# Altium schematic sheet 04 - USB, QSPI, SHA, I2C EEPROM.SchDoc
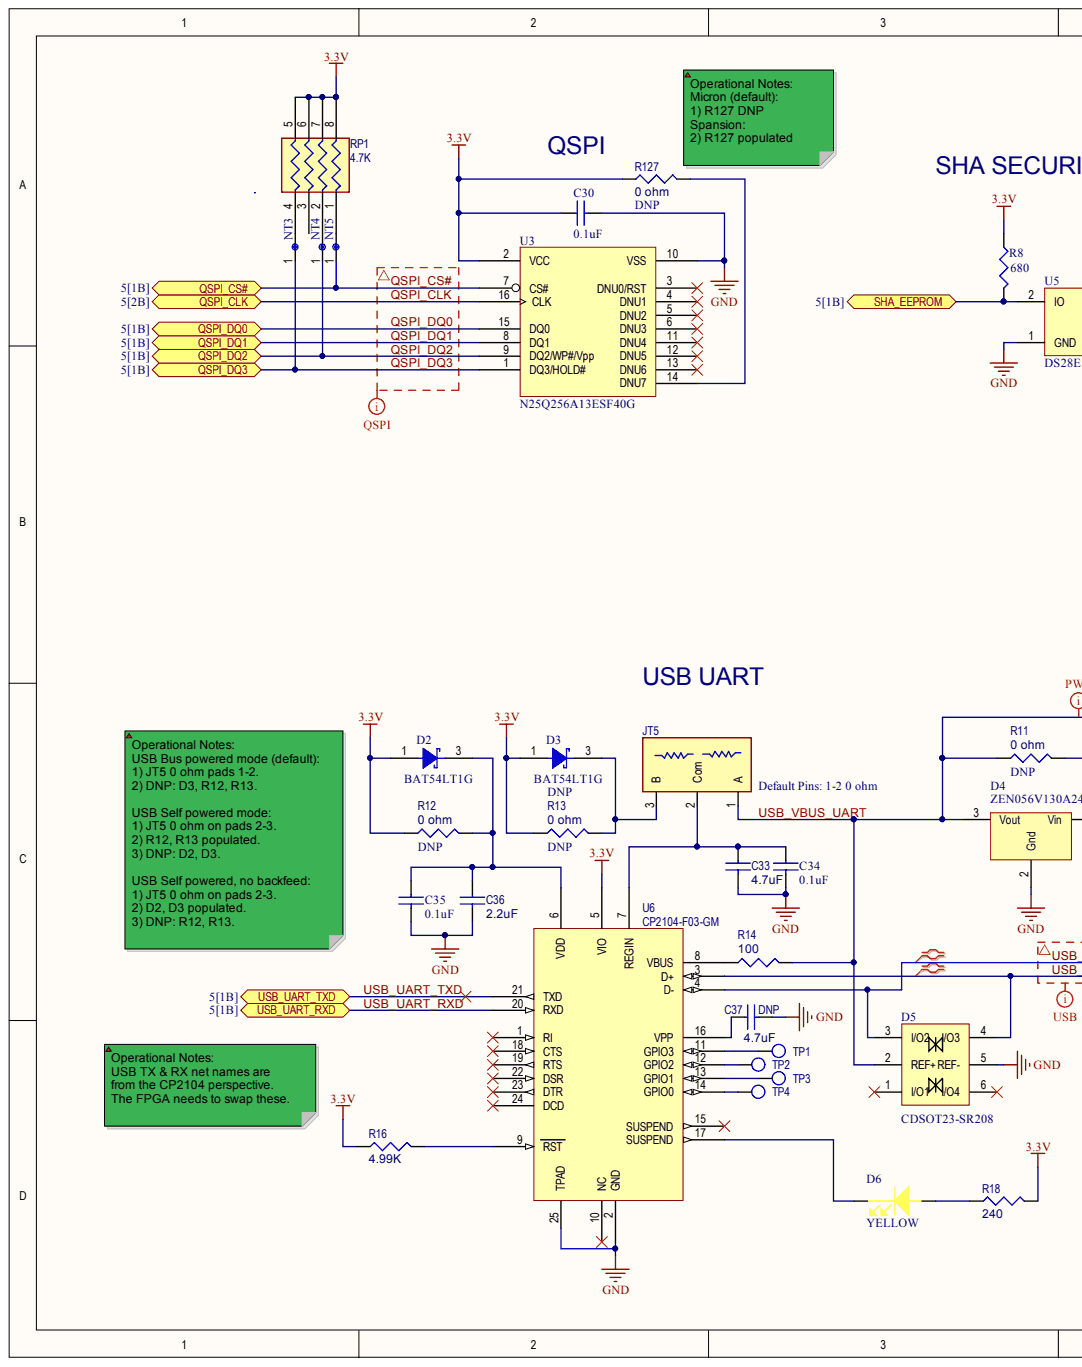
[diagram p0: 04 - USB, QSPI, SHA, I2C EEPROM.SchDoc, left]
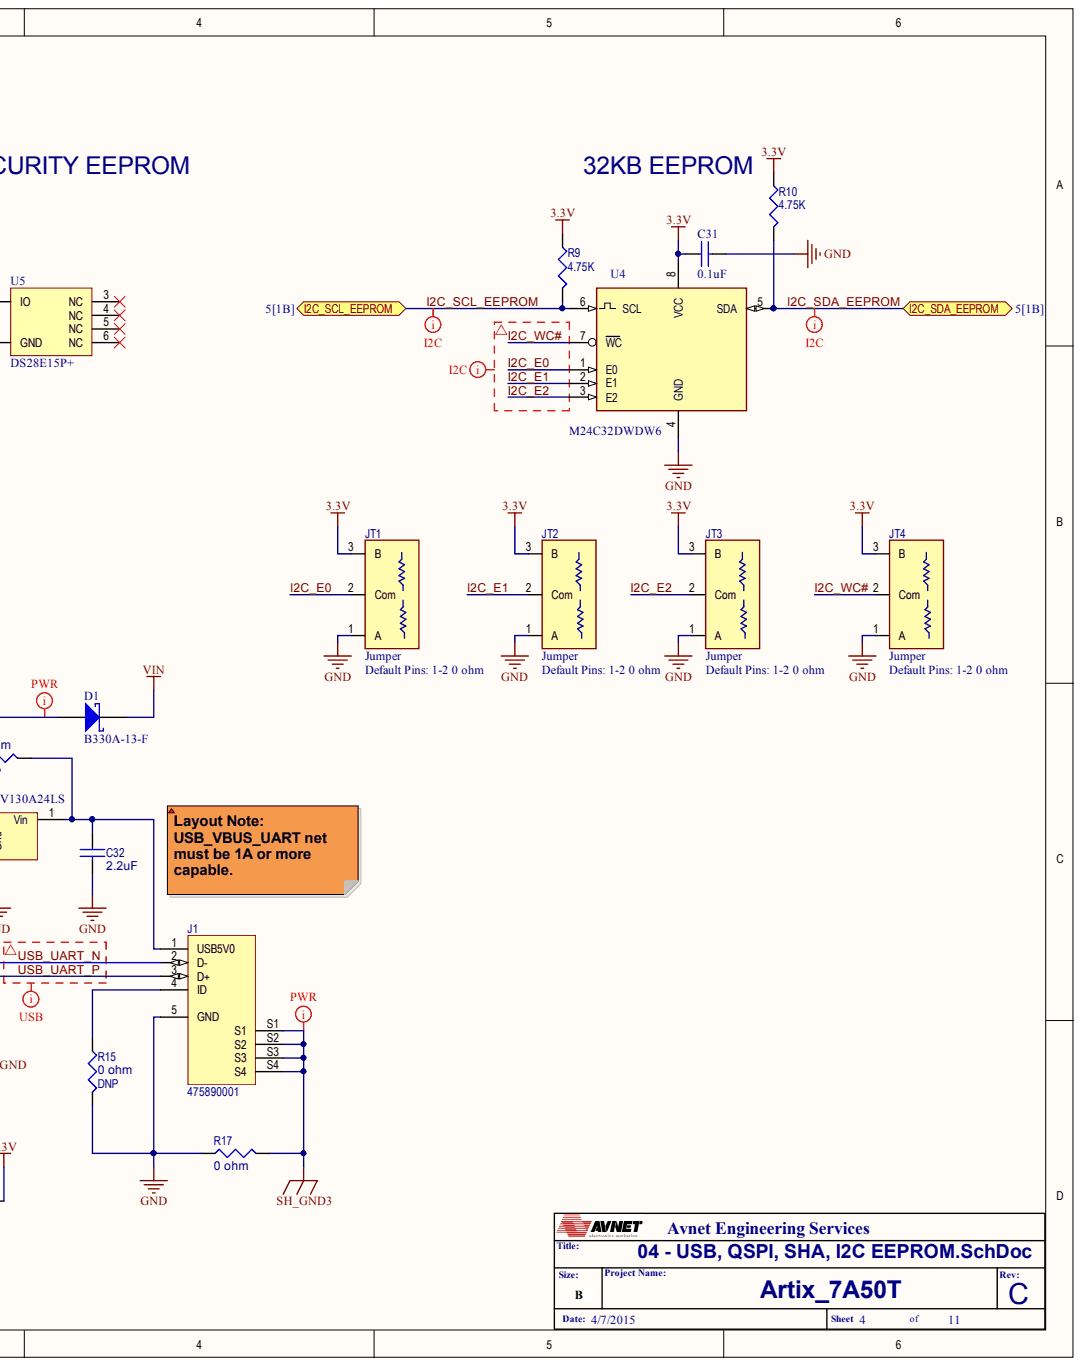
[diagram p1: 04 - USB, QSPI, SHA, I2C EEPROM.SchDoc, right]

## Parts
C30  value 0.1uF  [C0201]  (hidden comment: PC522)
C31  value 0.1uF  [C0201]  (hidden comment: PC522)
C32  2.2uF  [C0603]
C33  value 4.7uF  [C0402]  (hidden comment: 4.8uF)
C34  value 0.1uF  [C0201]  (hidden comment: PC522)
C35  value 0.1uF  [C0201]  (hidden comment: PC522)
C36  2.2uF  [C0603]
C37  value 4.7uF  [C0402]  (hidden comment: 4.8uF)
D1  Device: B330A-13-F  [SMA]  (hidden comment: DD031)
D2  BAT54LT1G  [SOT23]
D3  BAT54LT1G  [SOT23]
D4  Device: ZEN056V130A24LS  [PolyZen]  (hidden comment: POLY_ZEN)
D5  CDSOT23-SR208  [SOT23-6]
D6  Device: YELLOW  [LED_LITE-LTST-C191TBKT-Y]  (hidden comment: DL017)
J1  475890001  [MOLEX_0475890001]
JT1  value 0 ohm  Device: Jumper  [R0402-DUAL_JMP]
JT2  value 0 ohm  Device: Jumper  [R0402-DUAL_JMP]
JT3  value 0 ohm  Device: Jumper  [R0402-DUAL_JMP]
JT4  value 0 ohm  Device: Jumper  [R0402-DUAL_JMP]
JT5  value 0 ohm  [R0402-DUAL_JMP]
NT3  PO030  [NT2X4-2210]  (value not drawn)
NT4  PO030  [NT2X4-2210]  (value not drawn)
NT5  PO030  [NT2X4-2210]  (value not drawn)
R8  680R  value 680  [R0603]
R9  value 4.75K  [R0402]  (hidden comment: 0402)
R10  value 4.75K  [R0402]  (hidden comment: 0402)
R11  0 ohm  [R0402]
R12  0 ohm  [R0402]
R13  0 ohm  [R0402]
R14  100  [R0402]
R15  0 ohm  [R0402]
R16  4.99K  [R0402]
R17  0 ohm  [R0402]
R18  240  [R0402]
R127  0 ohm  [R0402]
RP1  value 4.7K  [RPACK4-LCC]  (hidden comment: CAT16-472J4LF)
TP1  TPS  [TP24TH]  (value not drawn)
TP2  TPS  [TP24TH]  (value not drawn)
TP3  TPS  [TP24TH]  (value not drawn)
TP4  TPS  [TP24TH]  (value not drawn)
U3  N25Q256A13ESF40G  [so16L]
U4  MFGPN: M24C32DWDW6  [TSSOP8]  (hidden comment: ST_M24C32_TSSOP)
U5  DS28E15P+  [TSOC6]
U6  CP2104-F03-GM  [QFN24_4x4mm]

## Ports
I2C_SCL_EEPROM, I2C_SDA_EEPROM, QSPI_CLK, QSPI_CS#, QSPI_DQ0, QSPI_DQ1, QSPI_DQ2, QSPI_DQ3, SHA_EEPROM, USB_UART_RXD, USB_UART_TXD

## Nets
3.3V: C30.1 C31.1 D2.1 D3.1 JT1.3 JT2.3 JT3.3 JT4.3 R8.1 R9.1 R10.1 R12.1 R13.1 R16.1 R18.2 R127.1 RP1.5 RP1.6 RP1.7 RP1.8 U3.2(VCC) U4.8(VCC) U6.5(VIO)
GND: C30.2 C31.2 C32.2 C33.2 C34.2 C35.2 C36.2 C37.2 D4.2 D5.5(REF-) J1.5(GND) JT1.1 JT2.1 JT3.1 JT4.1 R15.2 R17.1 U3.10(VSS) U4.4(GND) U5.1(GND) U6.2(GND) U6.25(TPAD)
SH_GND3: J1.S1 J1.S2 J1.S3 J1.S4 R17.2
VIN: D1.C
I2C_E0: JT1.2 U4.1(E0)
I2C_E1: JT2.2 U4.2(E1)
I2C_E2: JT3.2 U4.3(E2)
I2C_SCL_EEPROM: R9.2 U4.6 ; port I2C_SCL_EEPROM
I2C_SDA_EEPROM: R10.2 U4.5(SDA) ; port I2C_SDA_EEPROM
I2C_WC#: JT4.2 U4.7(WC)
QSPI_CLK: U3.16(CLK) ; port QSPI_CLK
QSPI_CS#: NT5.1 U3.7(CS#) ; port QSPI_CS#
QSPI_DQ0: U3.15(DQ0) ; port QSPI_DQ0
QSPI_DQ1: U3.8(DQ1) ; port QSPI_DQ1
QSPI_DQ2: NT4.1 U3.9(DQ2/WP#/Vpp) ; port QSPI_DQ2
QSPI_DQ3: NT3.1 U3.1(DQ3/HOLD#) ; port QSPI_DQ3
SHA_EEPROM: R8.2 U5.2(IO) ; port SHA_EEPROM
USB_UART_N: D5.3(I/O2) J1.2(D-) U6.4(D-)
USB_UART_P: D5.4(I/O3) J1.3(D+) U6.3(D+)
USB_UART_RXD: U6.20(RXD) ; port USB_UART_RXD
USB_UART_TXD: U6.21(TXD) ; port USB_UART_TXD
USB_VBUS_UART: D1.A D4.3 D5.2(REF+) JT5.1 R11.1 R14.2
NetC32_1: C32.1 D4.1 J1.1(USB5V0) R11.2
NetC33_1: C33.1 C34.1 JT5.2 U6.7(REGIN)
NetC35_1: C35.1 C36.1 D2.3 R12.2 U6.6(VDD)
NetC37_1: C37.1 U6.16(VPP)
NetD3_3: D3.3 JT5.3 R13.2
NetD6_A: D6.A R18.1
NetD6_C: D6.C U6.17(SUSPEND)
NetJ1_4: J1.4(ID) R15.1
NetNT3_2: NT3.2 RP1.4
NetNT4_2: NT4.2 RP1.2
NetNT5_2: NT5.2 RP1.1
NetR14_1: R14.1 U6.8(VBUS)
NetR16_2: R16.2 U6.9(RST)
NetR127_2: R127.2 U3.14(DNU7)
NetTP1_1: TP1.1 U6.11(GPIO3)
NetTP2_1: TP2.1 U6.12(GPIO2)
NetTP3_1: TP3.1 U6.13(GPIO1)
NetTP4_1: TP4.1 U6.14(GPIO0)
N00105:
N00106:
N00107:

Not connected: D5 1(I/O1) 6(I/O4); RP1 3; U3 3(DNU0/RST) 4(DNU1) 5(DNU2) 6(DNU3) 11(DNU4) 12(DNU5) 13(DNU6); U5 3(NC) 4(NC) 5(NC) 6(NC); U6 1(RI) 10(NC) 15(SUSPEND) 18(CTS) 19(RTS) 22(DSR) 23(DTR) 24(DCD)

## Library data of the parts
C30 C31 C34 C35 = AVPC-0000522: CAP SM 0.1uF 10V 10% X5R C0201; PartCode: PC; Database Table Name: Passive-Cap$; MFG Code: AVX; MFGPN: 02016D104KAT2A; AsyTxt: PC522; AVLNo: AVPC-0000522; Component Kind: Standard; ComponentLink1Description: Datasheet; Device: CAP; Dielectric: X5R; PkgType: SM; RoHS: Yes; Status: Preliminary; Tol: 10%; Volt: 10V; ComponentLink1URL: C:\AVDBLIB\DATASHEETS\AVX_CCOG.pdf; Library Name: Avnet_DBlib.DbLib
C32 C36 = AVPC-0000155: CAP SM 2.2uF 6.3V 10% X5R C0603; PartCode: PC; AsyTxt: PC155; Database Table Name: Passive-Cap$; MFG Code: AVX; MFGPN: 06036D225KAT2A; Power: 0; Availability: 4K-AV; AVLNo: AVPC-0000155; Component Kind: Standard; ComponentLink1Description: Datasheet; ComponentLink2Description: MFR Website; ComponentLink2URL: www.avx.com; Cost: 0.04; Device: CAP; Dielectric: X5R; PkgType: SM; Received: 0; RoHS: Yes; Size: 3.4; Status: Preliminary; Tol: 10%; Value: 2.2uF; Volt: 6.3V; ComponentLink1URL: C:\AVDBLIB\DATASHEETS\AVX_CX5R.pdf; Library Name: Avnet_DBlib.DbLib
C33 C37 = AVPC-0000499: CAP SM 4.7uF 6.3V 20% X5R C0402 85C; PartCode: PC; AsyTxt: PC499; Database Table Name: Passive-Cap$; MFG Code: AVX; MFGPN: GRM155R60J475ME87D; Power: 0; Availability: 140K-DK; AVLNo: AVPC-0000499; Component Kind: Standard; ComponentLink1Description: Datasheet; Cost: .46; Device: CAP; Dielectric: X5R; PkgType: SM; Received: 0; RoHS: Yes; Size: 1.9; Status: Preliminary; Tol: 20%; Volt: 6.3V; ComponentLink1URL: C:\AVDBLIB\DATASHEETS\murata_caps_c02e.pdf; Library Name: Avnet_DBlib.DbLib
  C37: DNP: DNP
D1 = AVDD-0000031: Diode SM Barrier Rectifier Schottky 3A 30V    DIO-S SMA; PartCode: DD; Database Table Name: Discrete-Diode$; MFG Code: DII; AsyTxt: DD031; AVLNo: AVDD-0000031; Component Kind: Standard; Device Sub Type: Barrier Rectifier; Device Type: Diode; Imax: 3A; MFGPN: B330A-13-F; PkgType: SM; Status: Preliminary; Technology: Schottky; Vr: 30V; Library Name: Avnet_DBlib.DbLib
D2 D3 = BAT54LT1G: Schottky Diodes Vf=0.35V@10mA Vr=30V; Component Kind: Standard; Library Name: new.SchLib; MFGPN: BAT54LT1G
  D3: DNP: DNP
D4 = AVDO-0000003: OVP SM Over Voltage Protection 1.3A 24V 5.6V   POLY_ZEN PolyZen; PartCode: DO; AsyTxt: DO003; Database Table Name: Discrete-Other$; MFG Code: RCP; AVLNo: AVDO-0000003; Component Kind: Standard; ComponentLink1Description: Datasheet; Device Type: OVP; Imax: 1.3A; MFGPN: ZEN056V130A24LS; PkgType: SM; RoHS: YES; Status: Preliminary; Technology: Over Voltage Protection; Vf: 5.6V; Vr: 24V; ComponentLink1URL: C:\AVDBLIB\DATASHEETS\polyzends.pdf; Library Name: Avnet_DBlib.DbLib
D5 = CDSOT23-SR208: ESD Protection array; AVLNo: AVDO-0000008; MFG: BNS; Component Kind: Standard; Device: CDSOT23-SR208; Library Name: Zynq_Z010_ZedStick.SchLib; MFGPN: CDSOT23-SR208
D6 = AVDL-0000017: LED, Yel, 585nm, Vf=2.1V, If=10mA, lv=6mcd; PartCode: DL; Database Table Name: Discrete-LED$; If: 10mA; LV: 6mcd; MFG Code: LTP; MFGPN: LTST-C190YKT; PkgType: SM; Vf: 2.1V; AsyTxt: DL017; AVLNo: AVDL-0000017; Component Kind: Standard; ComponentLink1Description: Datasheet; Status: Preliminary; WaveLength: 585nM; ComponentLink1URL: C:\AVDBLIB\DATASHEETS\ltst-c190ykt.pdf; Library Name: Avnet_DBlib.DbLib
J1 = AVEC-0000011,A-0: CONN RCPT MICRO USB AB R/A SMD; Mount Type (SM/TH): SM; Preferred MFG: MOLEX; Preferrence Code: A; Component Kind: Standard; Component Type: CONNECTOR; Material: MICRO USB; PCB Footprints: MOLEX_0475890001; Preferred MFGPN: 475890001; RoHS: Y; Library Name: AES ALTIUM VAULT
JT1-JT4 = AVPO-0000025: Jumper 3-pad 0402 RES-3padJPR R0402-DUAL_JMP - 0 Ohm at Pads 1-2; PartCode: PO; Database Table Name: Passive-Other$; Default Pins: 1-2 0 ohm; Device Type: 3-pad 0R0 0402; MFG Code: DAL; MFGPN: CRCW04020000Z0ED: Placed at pads 1-2; PkgType: SM; Component Kind: Standard; ComponentLink1Description: Datasheet; RoHS: Yes; Status: Preliminary; ComponentLink1URL: C:\AVDBLIB\DATASHEETS\Dale_CRCW.pd; Library Name: Avnet_DBlib.DbLib
JT5 = AVPO-0000025: Jumper 3-pad 0402 RES-3padJPR R0402-DUAL_JMP - 0 Ohm at Pads 1-2; PartCode: PO; Database Table Name: Passive-Other$; Default Pins: 1-2 0 ohm; Device Type: 3-pad 0R0 0402; MFG Code: DAL; MFGPN: CRCW04020000Z0ED: Placed at pads 1-2; PkgType: SM; Value: 0 ohm; Component Kind: Standard; ComponentLink1Description: Datasheet; Device: Jumper; RoHS: Yes; Status: Preliminary; ComponentLink1URL: C:\AVDBLIB\DATASHEETS\Dale_CRCW.pd; Library Name: Avnet_DBlib.DbLib
NT3-NT5 = AVPO-0000030: Net Tie 2 points; PartCode: PO; Database Table Name: Passive-Other$; MFG Code: None; PkgType: SM; AsyTxt: PO030; AVLNo: AVPO-0000030; Component Kind: Standard; ComponentLink1Description: Datasheet; MFGPN: None; RoHS: Yes; Status: Preliminary; ComponentLink1URL: C:\AVDBLIB\DATASHEETS\; Library Name: Avnet_DBlib.DbLib
R8 = RES: Resistor; MFG#1/Part#: Vishay: CRCW0603680RJNEA; MFGPN: CRCW0603680RJNEA; Component Kind: Standard; Library Name: HKADS_Lib.IntLib
R9 R10 = RESC 4K75 0402: Res Thick Film 0402 4.75K Ohm 1% 1/16W ±100ppm/°C Molded SMD SMD Paper T/R; MFG Code: DAL; MFGPN: CRCW04024K75FKED; Component Kind: Standard; Note: Res Thick Film 0402 4.75K Ohm 1% 1/16W ±100ppm/°C Molded SMD SMD Paper T/R; Signal Integrity: RES; Simulation: RES; Library Name: ZYNQ_Z7045_MITX_REVA.SCHLIB
R11-R13 R15 R17 R127 = AVPR-0000027: RES SM 0 ohm 62mW J R0402; PartCode: PR; AsyTxt: PR027; Database Table Name: Passive-Res$; MFG Code: DAL; MFGPN: CRCW04020000Z0ED; AVLNo: AVPR-0000027; Component Kind: Standard; ComponentLink1Description: Datasheet; Device: RES; PkgType: SM; RoHS: Yes; Status: Preliminary; Value: 0 ohm; Watt: 62mW; ComponentLink1URL: C:\AVDBLIB\DATASHEETS\Dale_CRCW.pd; Library Name: Avnet_DBlib.DbLib
  R11-R13 R15 R127: DNP: DNP
R14 = AVPR-0000027: RES SM 100 ohm 62mW J R0402; PartCode: PR; AsyTxt: PR027; AVLNo: AVPR-0000477; Database Table Name: Passive-Res$; MFG Code: DAL; MFGPN: CR0402-JW-101GLF; Component Kind: Standard; ComponentLink1Description: Datasheet; Device: RES; PkgType: SM; RoHS: Yes; Status: Preliminary; Value: 100; Watt: 62mW; ComponentLink1URL: C:\AVDBLIB\DATASHEETS\Dale_CRCW.pd; Library Name: Avnet_DBlib.DbLib
R16 = AVPR-0000094: RES SM 4.99K 62mW 0.01 R0402; PartCode: PR; AsyTxt: PR094; Database Table Name: Passive-Res$; MFG Code: DAL; MFGPN: CRCW04024K99FKED; Tol: 0.01; AVLNo: AVPR-0000094; Component Kind: Standard; ComponentLink1Description: Datasheet; Device: RES; PkgType: SM; RoHS: Yes; Status: Preliminary; Value: 4.99K; Watt: 62mW; ComponentLink1URL: C:\AVDBLIB\DATASHEETS\Dale_CRCW.pd; Library Name: Avnet_DBlib.DbLib
R18 = AVPR-0000511: RES SM 240 62mW 0.01 R0402; Alt1MFR: NIC; PartCode: PR; Alt1PN: NRC04F2400TRF; AsyTxt: PR511; Database Table Name: Passive-Res$; MFG Code: DAL; MFGPN: CRCW0402240RFKED; Power: 0; Tol: 0.01; Availability: 1M-AV; AVLNo: AVPR-0000511; Component Kind: Standard; ComponentLink1Description: Datasheet; Cost: .01; Device: RES; PkgType: SM; Received: 0; RoHS: Yes; Size: 1.9; Status: Preliminary; Value: 240; Watt: 62mW; ComponentLink1URL: C:\AVDBLIB\DATASHEETS\Dale_CRCW.pd; Library Name: Avnet_DBlib.DbLib
RP1 = RESCAXE 4.7K: Res Thick Film Array 4.7K Ohm 5% ISOL Molded 8-Pin 1206 Concave SMD Paper T/R; MFG Code: BNS; Component Kind: Standard; MFGPN: CAT16-472J4LF; Note: Res Thick Film Array 4.7K Ohm 5% ISOL Molded 8-Pin 1206 Concave SMD Paper T/R; Library Name: ZYNQ_Z7045_MITX_REVA.SCHLIB
TP1-TP4 = AVPO-0000039: Test Point 24 mil pad, round, 10 mil hole, small symbol TPS TP24TH; MFG Code: None; Component Kind: Standard; DNP: DNP; MFGPN: None; Library Name: ZYNQ_Z7045_MITX_REVA.SCHLIB
U3 = N25Q256A13ESF40G: 32MB QSPI; Component Kind: Standard; Library Name: Artix_7A50.SchLib; MFGPN: N25Q256A13ESF40G
U4 = ST_M24C32_TSSOP: I2C SEEPROM, 32KB; Component Kind: Standard; Library Name: Artix_7A50.SchLib; PKG: TSSOP8
U5 = DS28E01P+: 1 Wire EEPROM; MFG#1/Part#: Maxim: DS28E15P+; MFG: MXM; Component Kind: Standard; MFGPN: DS28E15P+; Library Name: HKADS_Lib.IntLib
U6 = (no symbol name): USB UART Bridge; PartCode: IY; AVLNo: AVIY-0000046; Device: CP2104; MFG Code: SLL; PkgType: SM; Ports/Channels: 1; Version/Level: USB to Uart; Component Kind: Standard; Library Name: Zynq_Z010_ZedStick.SchLib; Medium: USB; MFGPN: CP2104-F03-GM; RoHS: Yes; Status: Preliminary
